# Altium schematic sheet Gate Driver & H-Bridge.SchDoc("Gate Driver & H-Bridge")
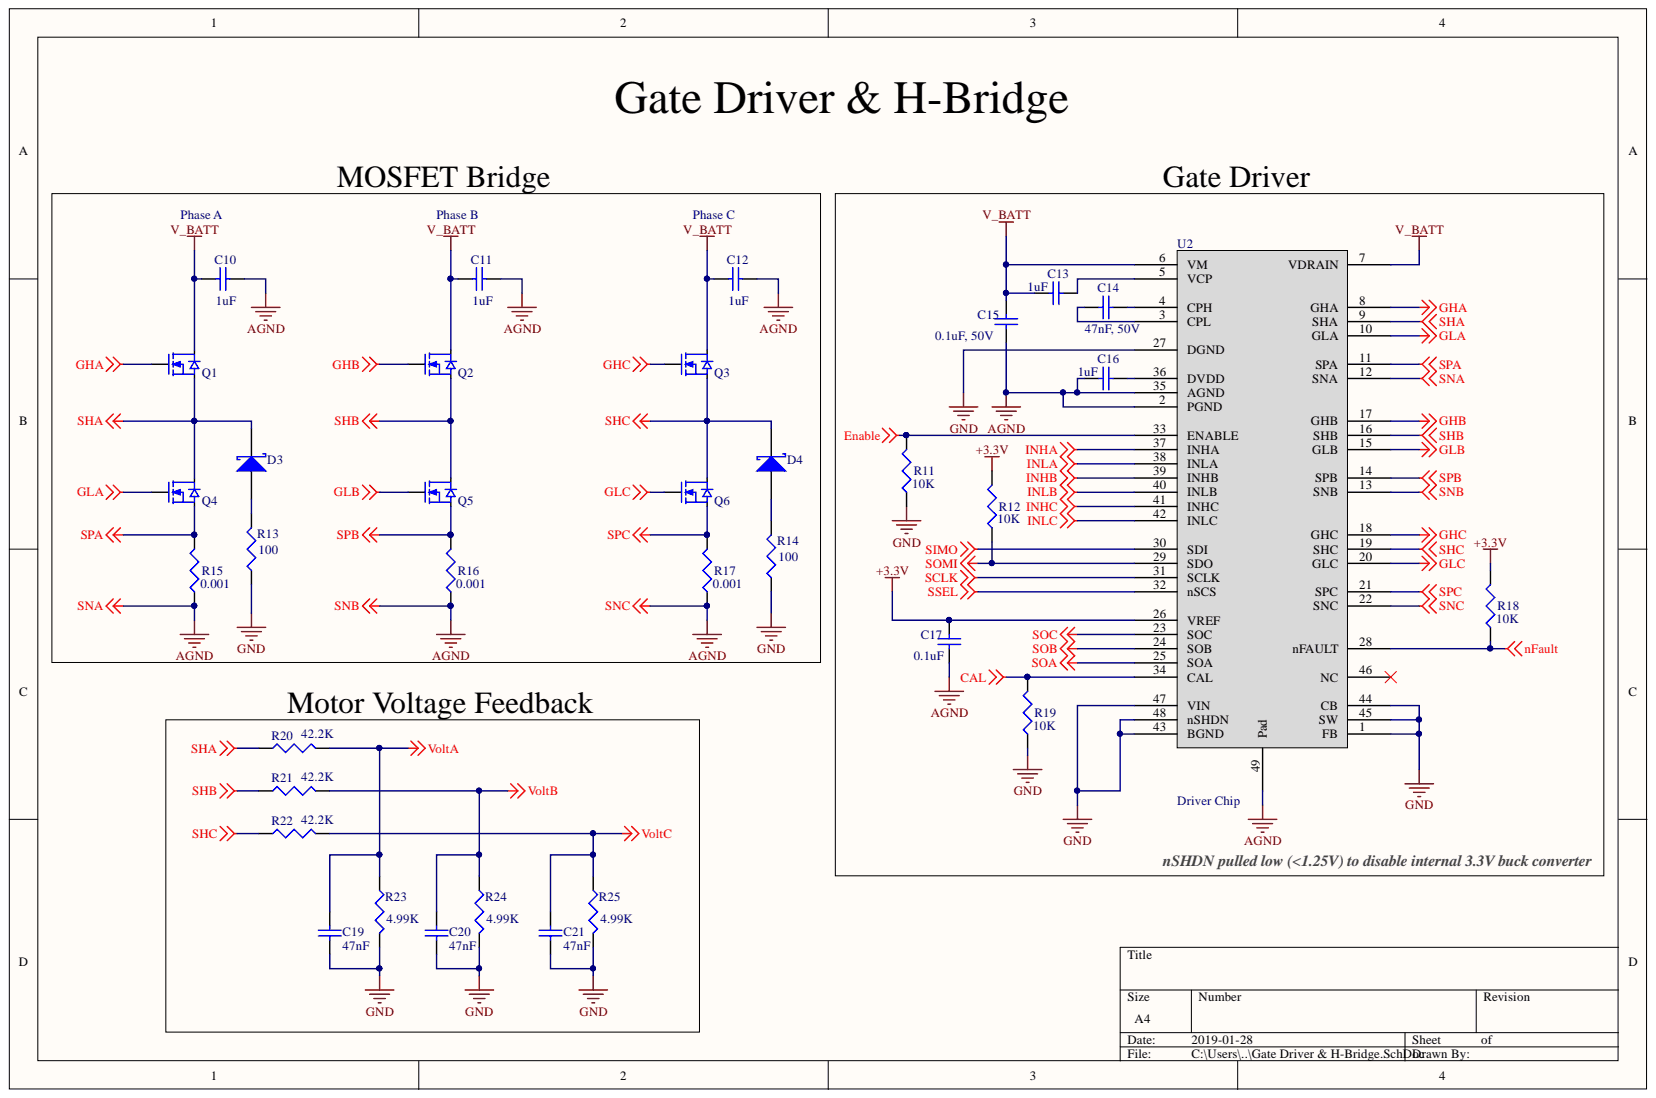
[diagram p0: Gate Driver & H-Bridge.SchDoc]

## Parts
C10  value 1uF  [CAPC2012X06N]  (hidden comment: Cap)
C11  value 1uF  [CAPC2012X06N]  (hidden comment: Cap)
C12  value 1uF  [CAPC2012X06N]  (hidden comment: Cap)
C13  value 1uF  [CAPC2012X06N]  (hidden comment: Cap)
C14  value 47nF, 50V  [0603 CAP Medium]  (hidden comment: Cap)
C15  value 0.1uF, 50V  [0603 CAP Medium]  (hidden comment: Cap)
C16  value 1uF  [CAPC2012X06N]  (hidden comment: Cap)
C17  value 0.1uF  [0603 CAP Medium]  (hidden comment: Cap)
C19  value 47nF  [0603 CAP Medium]  (hidden comment: Cap)
C20  value 47nF  [0603 CAP Medium]  (hidden comment: Cap)
C21  value 47nF  [0603 CAP Medium]  (hidden comment: Cap)
D3  Diode 11DQ03  [Zener]  (value not drawn)
D4  Diode 11DQ03  [Zener]  (value not drawn)
Q1  IPB180N04S400ATMA1  (value not drawn)
Q2  IPB180N04S400ATMA1  (value not drawn)
Q3  IPB180N04S400ATMA1  (value not drawn)
Q4  IPB180N04S400ATMA1  (value not drawn)
Q5  IPB180N04S400ATMA1  (value not drawn)
Q6  IPB180N04S400ATMA1  (value not drawn)
R11  value 10K  [RES 0603 MEDIUM]  (hidden comment: Res3)
R12  value 10K  [RES 0603 MEDIUM]  (hidden comment: Res3)
R13  value 100  [RES 0603 MEDIUM]  (hidden comment: Res3)
R14  value 100  [RES 0603 MEDIUM]  (hidden comment: Res3)
R15  value 0.001  [RESC8032X08N]  (hidden comment: Res3)
R16  value 0.001  [RESC8032X08N]  (hidden comment: Res3)
R17  value 0.001  [RESC8032X08N]  (hidden comment: Res3)
R18  value 10K  [RES 0603 MEDIUM]  (hidden comment: Res3)
R19  value 10K  [RES 0603 MEDIUM]  (hidden comment: Res3)
R20  value 42.2K  [RES 0603 MEDIUM]  (hidden comment: Res3)
R21  value 42.2K  [RES 0603 MEDIUM]  (hidden comment: Res3)
R22  value 42.2K  [RES 0603 MEDIUM]  (hidden comment: Res3)
R23  value 4.99K  [RES 0603 MEDIUM]  (hidden comment: Res3)
R24  value 4.99K  [RES 0603 MEDIUM]  (hidden comment: Res3)
R25  value 4.99K  [RES 0603 MEDIUM]  (hidden comment: Res3)
U2  Driver Chip  [QFN50P700X700X100_HS-49N]

## Nets
+3.3V: C17.2 R12.2 R18.2 U2.26(VREF)
CAL: R19.2 U2.34(CAL)
Enable: R11.2 U2.33(ENABLE)
GHA: Q1.1 U2.8(GHA)
GHB: Q2.1 U2.17(GHB)
GHC: Q3.1 U2.18(GHC)
GLA: Q4.1 U2.10(GLA)
GLB: Q5.1 U2.15(GLB)
GLC: Q6.1 U2.20(GLC)
GND: C19.1 C20.1 C21.1 R11.1 R13.1 R14.1 R19.1 R23.2 R24.2 R25.2 U2.1(FB) U2.27(DGND) U2.43(BGND) U2.44(CB) U2.45(SW) U2.47(VIN) U2.48(nSHDN)
INHA: U2.37(INHA)
INHB: U2.39(INHB)
INHC: U2.41(INHC)
INLA: U2.38(INLA)
INLB: U2.40(INLB)
INLC: U2.42(INLC)
nFault: R18.1 U2.28(nFAULT)
SCLK: U2.31(SCLK)
SHA: D3.2 Q1.3 Q4.2 R20.1 U2.9(SHA)
SHB: Q2.3 Q5.2 R21.1 U2.16(SHB)
SHC: D4.2 Q3.3 Q6.2 R22.1 U2.19(SHC)
SIMO: U2.30(SDI)
SNA: C10.2 C11.2 C12.2 C15.1 C16.1 C17.1 R15.1 R16.1 R17.1 U2.2(PGND) U2.12(SNA) U2.13(SNB) U2.22(SNC) U2.35(AGND) U2.49(Pad)
SOA: U2.25(SOA)
SOB: U2.24(SOB)
SOC: U2.23(SOC)
SOMI: R12.1 U2.29(SDO)
SPA: Q4.3 R15.2 U2.11(SPA)
SPB: Q5.3 R16.2 U2.14(SPB)
SPC: Q6.3 R17.2 U2.21(SPC)
SSEL: U2.32(nSCS)
V_BATT: C10.1 C11.1 C12.1 C13.1 C15.2 Q1.2 Q2.2 Q3.2 U2.6(VM) U2.7(VDRAIN)
VoltA: C19.2 R20.2 R23.1
VoltB: C20.2 R21.2 R24.1
VoltC: C21.2 R22.2 R25.1
NetC13_2: C13.2 U2.5(VCP)
NetC14_1: C14.1 U2.3(CPL)
NetC14_2: C14.2 U2.4(CPH)
NetC16_2: C16.2 U2.36(DVDD)
NetD3_1: D3.1 R13.2
NetD4_1: D4.1 R14.2

Not connected: U2 46(NC)

## Library data of the parts
C10-C13 C15-C17 C19-C21 = Cap: Capacitor; Simulation: CAP; Signal Integrity: Cap; Published: 8-Jun-2000; PackageReference: RAD-0.3; PackageDescription: Radial Cap, Thru-Hole; 2 Leads; 0.3 in Pin Spacing; Library Name: Miscellaneous Devices.IntLib; LatestRevisionNote: Re-released for DXP Platform.; LatestRevisionDate: 17-Jul-2002; Component Kind: Standard; Publisher: Altium Limited
  C10-C13: ComponentLink1URL: https://www.digikey.ca/product-detail/en/samsung-electro-mechanics/CL21B105KBFNNNG/1276-6470-1-ND/5958098; ComponentLink1Description: 1uF, 50V
  C15 C17: ComponentLink1URL: https://www.digikey.ca/product-detail/en/samsung-electro-mechanics/CL10B104KB8WPNC/1276-6854-1-ND/5961713; ComponentLink1Description: 0.1uF, 50V
  C19-C21: ComponentLink1URL: https://www.digikey.ca/product-detail/en/samsung-electro-mechanics/CL10B473KB8NFNC/1276-2075-1-ND/3890161; ComponentLink1Description: 0.047uF, 50V
C14 = Cap: Capacitor; Value: 47nF, 50V; Simulation: CAP; Signal Integrity: Cap; Published: 8-Jun-2000; PackageReference: RAD-0.3; PackageDescription: Radial Cap, Thru-Hole; 2 Leads; 0.3 in Pin Spacing; Library Name: Miscellaneous Devices.IntLib; LatestRevisionNote: Re-released for DXP Platform.; LatestRevisionDate: 17-Jul-2002; ComponentLink1URL: https://www.digikey.ca/product-detail/en/samsung-electro-mechanics/CL10B473KB8NFNC/1276-2075-1-ND/3890161; ComponentLink1Description: 0.047uF, 50V; Component Kind: Standard; Publisher: Altium Limited
D3 D4 = Diode 11DQ03: Schottky Rectifier; Simulation: 11DQ03; Published: 8-Jun-2000; PackageReference: DO-204AL; PackageDescription: Diode, Axial; Body 5.2 x 2.7 mm (LxDia. max), Lead Dia. 0.86 mm (max); Library Name: Miscellaneous Devices.IntLib; LatestRevisionNote: Re-released for DXP Platform.; LatestRevisionDate: 17-Jul-2002; DatasheetVersion: Dec-1997; ComponentLink1URL: https://www.digikey.ca/product-detail/en/micro-commercial-co/SMBJ5362B-TP/SMBJ5362B-TPMSCT-ND/1636228; ComponentLink1Description: Zener; Component Kind: Standard; Code_JEDEC: DO-204-AL; Publisher: Altium Limited
Q1-Q6 = IPB180N04S400ATMA1: N-Channel 40V 180A (Tc) 300W (Tc) Surface Mount PG-TO263-7-3; Library Name: MOSFET Board Schematic Library.SchLib; ComponentLink1URL: https://www.digikey.ca/product-detail/en/Infineon+Technologies/IPB180N04S400ATMA1/IPB180N04S400ATMA1DKR-ND/6560174; ComponentLink1Description: NMOS; Component Kind: Standard
R11-R25 = Res3: Resistor; Simulation: RESISTOR; Signal Integrity: Res; Published: 8-Jun-2000; PackageReference: J1-0603; PackageDescription: Chip Resistor; Library Name: Miscellaneous Devices.IntLib; LatestRevisionNote: IPC-7351 Footprint Added.; LatestRevisionDate: 29-May-2009; Component Kind: Standard; Publisher: Altium Limited
  R11 R12 R18 R19: ComponentLink1URL: https://www.digikey.ca/product-detail/en/vishay-dale/CRCW060310K0FKEAHP/541-10.0KSCT-ND/2222780; ComponentLink1Description: 10KOhm
  R13 R14: ComponentLink1URL: https://www.digikey.ca/product-detail/en/vishay-dale/RCP0603W100RGEB/541-2653-2-ND/5481867; ComponentLink1Description: 100Ohm
  R15 R17: ComponentLink1URL: https://www.digikey.ca/product-detail/en/stackpole-electronics-inc/CSNL2512FT1L00/CSNL2512FT1L00CT-ND/1646259; ComponentLink1Description: CS Res
  R20-R22: ComponentLink1URL: https://www.digikey.ca/product-detail/en/stackpole-electronics-inc/RMCF0603FT42K2/RMCF0603FT42K2CT-ND/1943096; ComponentLink1Description: 42.2KOhm
  R23-R25: ComponentLink1URL: https://www.digikey.ca/product-detail/en/vishay-dale/CRCW06034K99FKEAC/541-3985-1-ND/7928668; ComponentLink1Description: 4.99KOhm
U2 = Driver Chip: Library Name: MOSFET Board Schematic Library.SchLib; ComponentLink1URL: https://www.digikey.ca/product-detail/en/texas-instruments/DRV8323RSRGZT/296-46943-1-ND/7356148; ComponentLink1Description: Gate Driver; Component Kind: Standard
